# Altium schematic sheet 12.Connectors.SchDoc(12.Connectors)
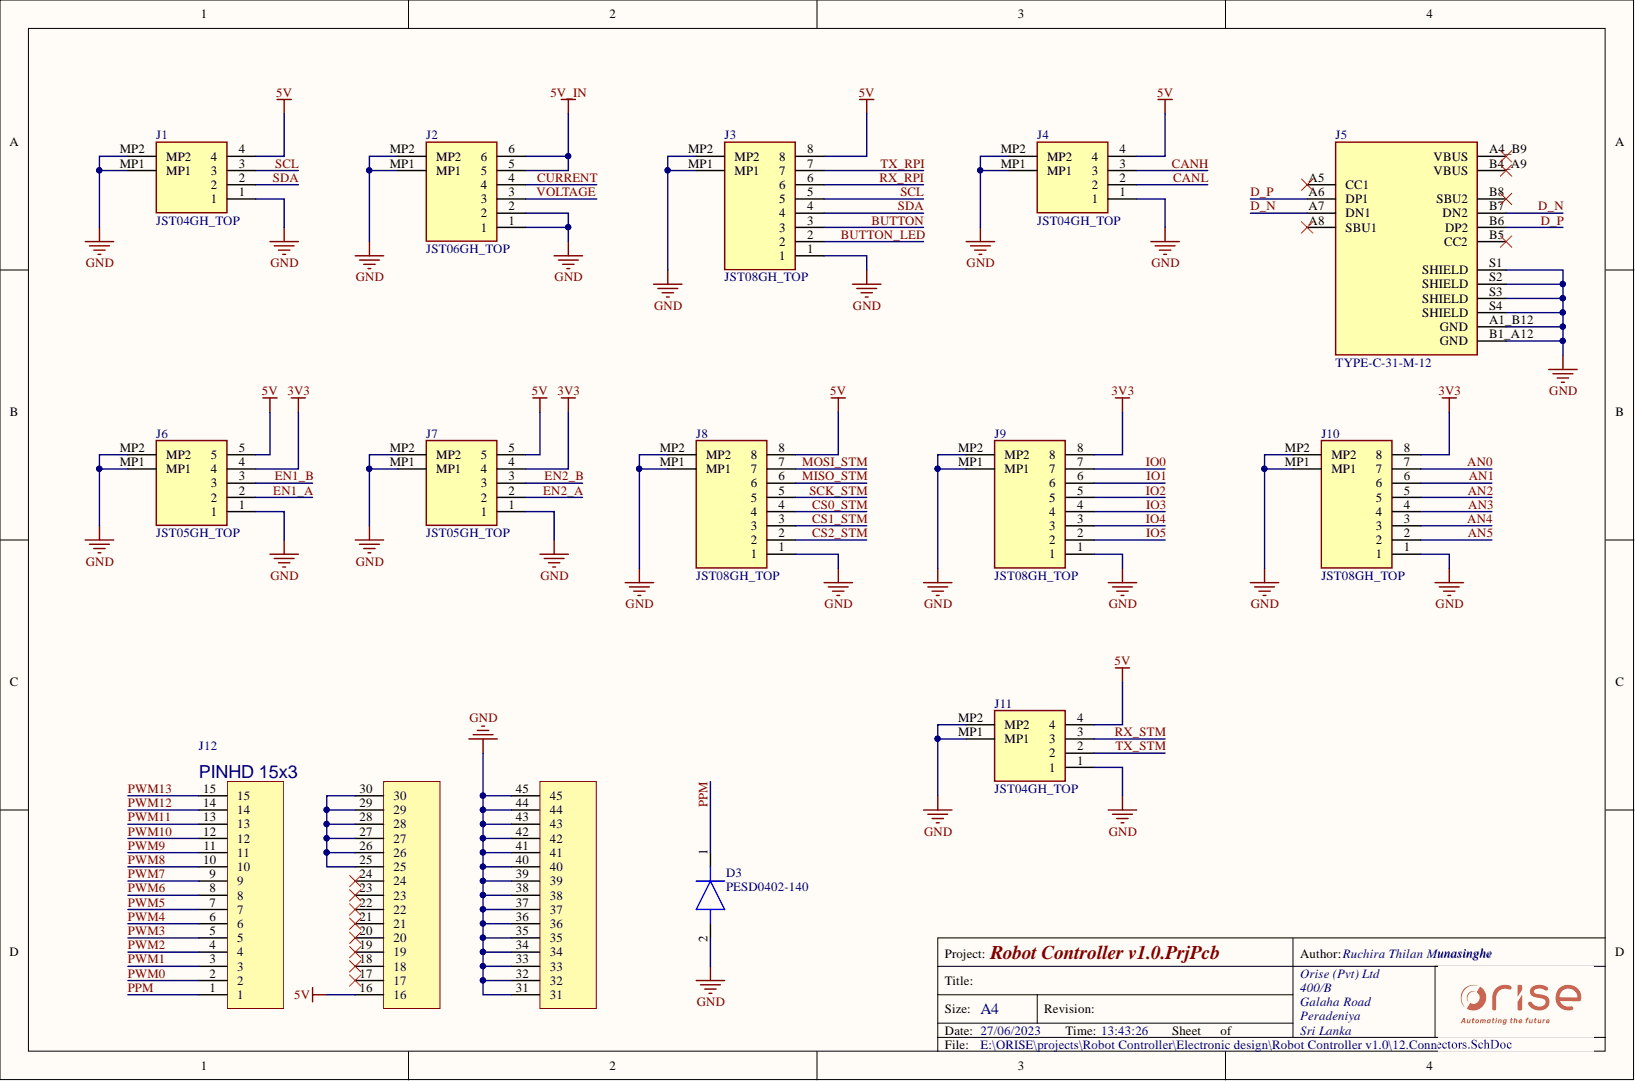
[diagram p0: 12.Connectors.SchDoc]

## Parts
D3  PESD0402-140  [DIONC1005X43N]
J1  JST04GH_TOP  [JST04GH_TOP]
J2  JST06GH_TOP  [JST06GH_TOP]
J3  JST08GH_TOP  [JST08GH_TOP]
J4  JST04GH_TOP  [JST04GH_TOP]
J5  TYPE-C-31-M-12  [HRO_TYPE-C-31-M-12]
J6  JST05GH_TOP  [JST05GH_TOP]
J7  JST05GH_TOP  [JST05GH_TOP]
J8  JST08GH_TOP  [JST08GH_TOP]
J9  JST08GH_TOP  [JST08GH_TOP]
J10  JST08GH_TOP  [JST08GH_TOP]
J11  JST04GH_TOP  [JST04GH_TOP]
J12  PINHD 15x3  [*TE_1-829492-2]

## Nets
3V3: J6.4 J7.4 J9.8 J10.8
5V: J1.4 J3.8 J4.4 J6.5 J7.5 J8.8 J11.4 J12.16
5V_IN: J2.5 J2.6
GND: D3.2 J1.1 J1.MP1 J1.MP2 J2.1 J2.2 J2.MP1 J2.MP2 J3.1 J3.MP1 J3.MP2 J4.1 J4.MP1 J4.MP2 J5.A1_B12(GND) J5.B1_A12(GND) J5.S1(SHIELD) J5.S2(SHIELD) J5.S3(SHIELD) J5.S4(SHIELD) J6.1 J6.MP1 J6.MP2 J7.1 J7.MP1 J7.MP2 J8.1 J8.MP1 J8.MP2 J9.1 J9.MP1 J9.MP2 J10.1 J10.MP1 J10.MP2 J11.1 J11.MP1 J11.MP2 J12.31 J12.32 J12.33 J12.34 J12.35 J12.36 J12.37 J12.38 J12.39 J12.40 J12.41 J12.42 J12.43 J12.44 J12.45
AN0: J10.7
AN1: J10.6
AN2: J10.5
AN3: J10.4
AN4: J10.3
AN5: J10.2
BUTTON: J3.3
BUTTON_LED: J3.2
CANH: J4.3
CANL: J4.2
CS0_STM: J8.4
CS1_STM: J8.3
CS2_STM: J8.2
CURRENT: J2.4
D_N: J5.A7(DN1) J5.B7(DN2)
D_P: J5.A6(DP1) J5.B6(DP2)
EN1_A: J6.2
EN1_B: J6.3
EN2_A: J7.2
EN2_B: J7.3
IO0: J9.7
IO1: J9.6
IO2: J9.5
IO3: J9.4
IO4: J9.3
IO5: J9.2
MISO_STM: J8.6
MOSI_STM: J8.7
PPM: D3.1 J12.1
PWM0: J12.2
PWM1: J12.3
PWM2: J12.4
PWM3: J12.5
PWM4: J12.6
PWM5: J12.7
PWM6: J12.8
PWM7: J12.9
PWM8: J12.10
PWM9: J12.11
PWM10: J12.12
PWM11: J12.13
PWM12: J12.14
PWM13: J12.15
RX_RPI: J3.6
RX_STM: J11.3
SCK_STM: J8.5
SCL: J1.3 J3.5
SDA: J1.2 J3.4
TX_RPI: J3.7
TX_STM: J11.2
VOLTAGE: J2.3
NetJ12_25: J12.25 J12.26 J12.27 J12.28 J12.29 J12.30

Not connected: J5 A4_B9(VBUS) A5(CC1) A8(SBU1) B4_A9(VBUS) B5(CC2) B8(SBU2); J12 17 18 19 20 21 22 23 24

## Library data of the parts
D3 = PESD0402-140: Diode; Manufacturer_Name: Littelfuse; Manufacturer_Part_Number: PESD0402-140; Library Name: Robot Controller v1.0.SchLib; LCSC Part Number: C10662; Component Kind: Standard
J1 J4 J11 = JST04GH_TOP: Connector Header Surface Mount, Straight 4 position 0.049" (1.25mm); Manufacturer Part Number: BM04B-GHS-TBT(LF)(SN); Manufacturer: JST Sales America Inc.; Library Name: Robot Controller v1.0.SchLib; LCSC Part Number: C161692; Height: 4.05mm; Datasheet Link: http://www.jst-mfg.com/product/pdf/eng/eGH.pdf; Component Kind: Standard
J2 = JST06GH_TOP: Connector Header Surface Mount, Straight 6 position 0.049" (1.25mm); Manufacturer Part Number: BM06B-GHS-TBT(LF)(SN)(N); Manufacturer: JST Sales America Inc.; Library Name: Robot Controller v1.0.SchLib; LCSC Part Number: C189892; Height: 4.05mm; Datasheet Link: http://www.jst-mfg.com/product/pdf/eng/eGH.pdf; Component Kind: Standard
J3 J8-J10 = JST08GH_TOP: Connector Header Surface Mount, Straight 8 position 0.049" (1.25mm); Manufacturer Part Number: BM08B-GHS-TBT(LF)(SN); Manufacturer: JST Sales America Inc.; Library Name: Robot Controller v1.0.SchLib; LCSC Part Number: C133062; Height: 4.05mm; Datasheet Link: http://www.jst-mfg.com/product/pdf/eng/eGH.pdf; Component Kind: Standard
J5 = TYPE-C-31-M-12: USB Connectors 24 Receptacle 1 8.94*7.3mm RoHS; Manufacturer: HRO Electronics Co., Ltd.; Manufacturer Part Number: TYPE-C-31-M-12; Library Name: Robot Controller v1.0.SchLib; LCSC Part Number: C165948; Component Kind: Standard
J6 J7 = JST05GH_TOP: Connector Header Surface Mount, Straight 5 position 0.049" (1.25mm); Manufacturer Part Number: BM05B-GHS-TBT(LF)(SN)(N); Manufacturer: JST Sales America Inc.; Library Name: Robot Controller v1.0.SchLib; LCSC Part Number: C189891; Height: 4.05mm; Datasheet Link: http://www.jst-mfg.com/product/pdf/eng/eGH.pdf; Component Kind: Standard
J12 = PINHD 15x3: PINHD 15x3 Pitch 2.54mm; Library Name: Robot Controller v1.0.SchLib; Component Kind: Standard
